# Altium schematic sheet ESP32S3_V2.1.SchDoc
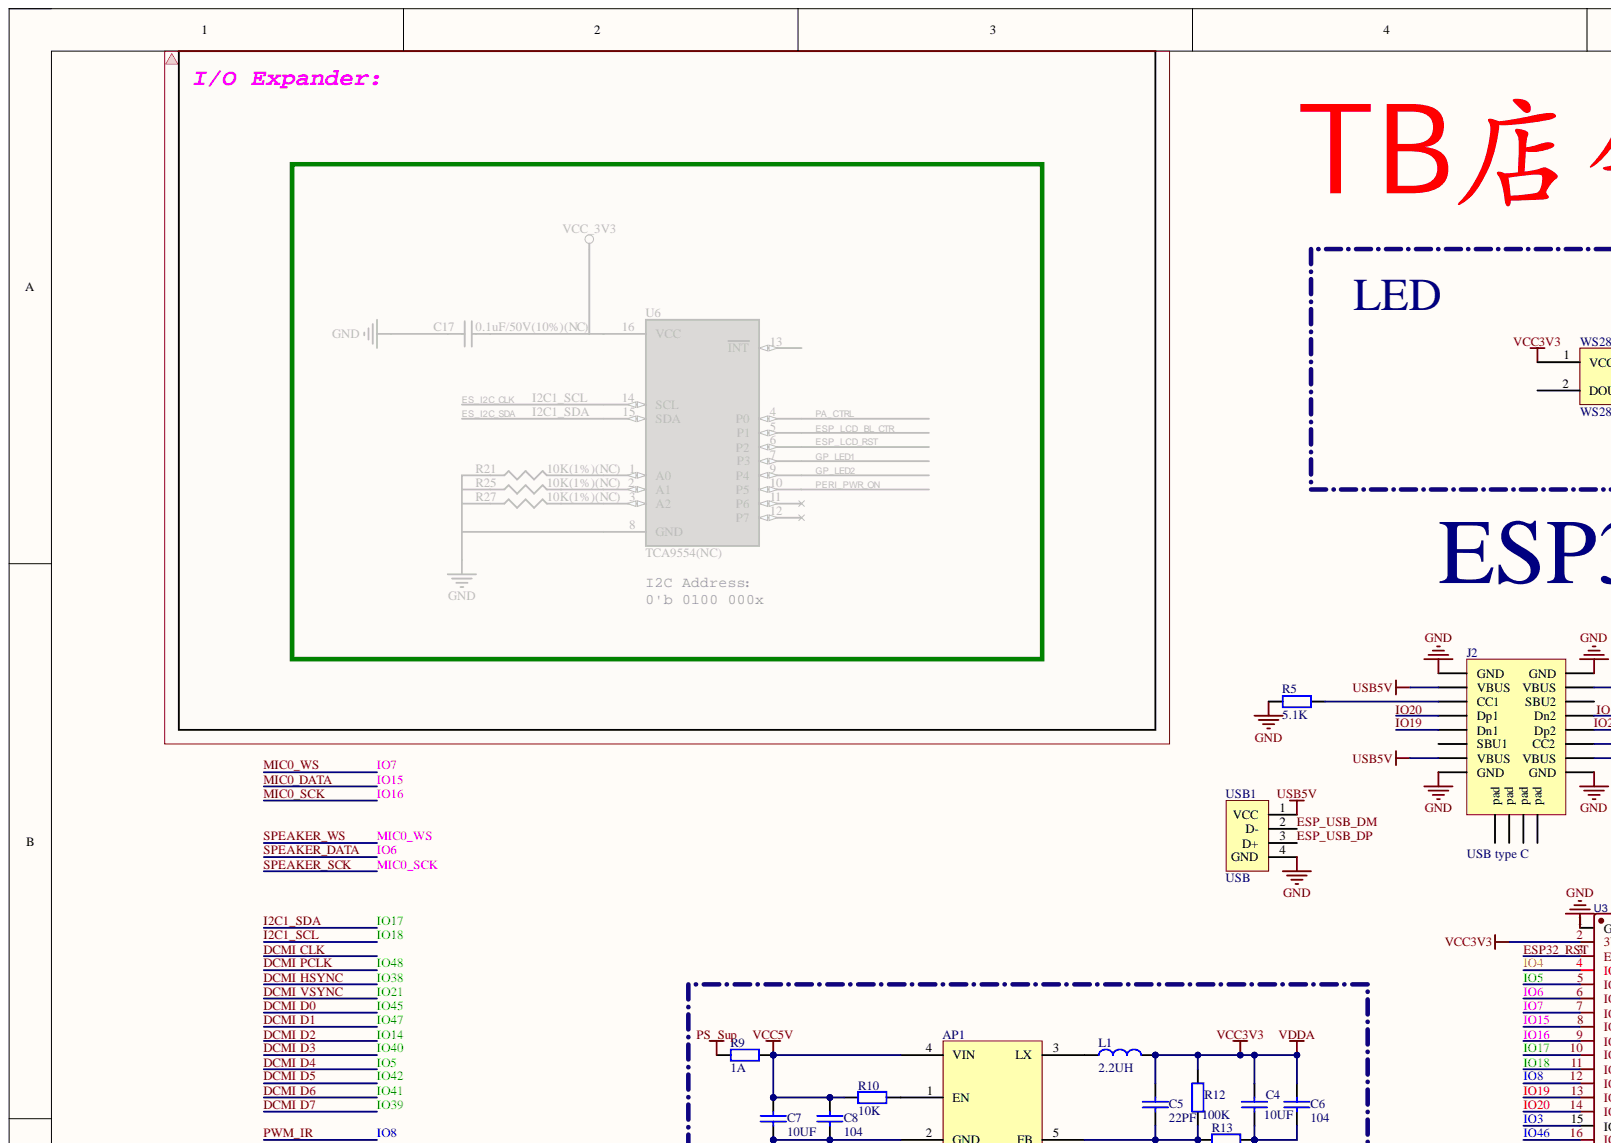
[diagram p0: ESP32S3_V2.1.SchDoc, top left]
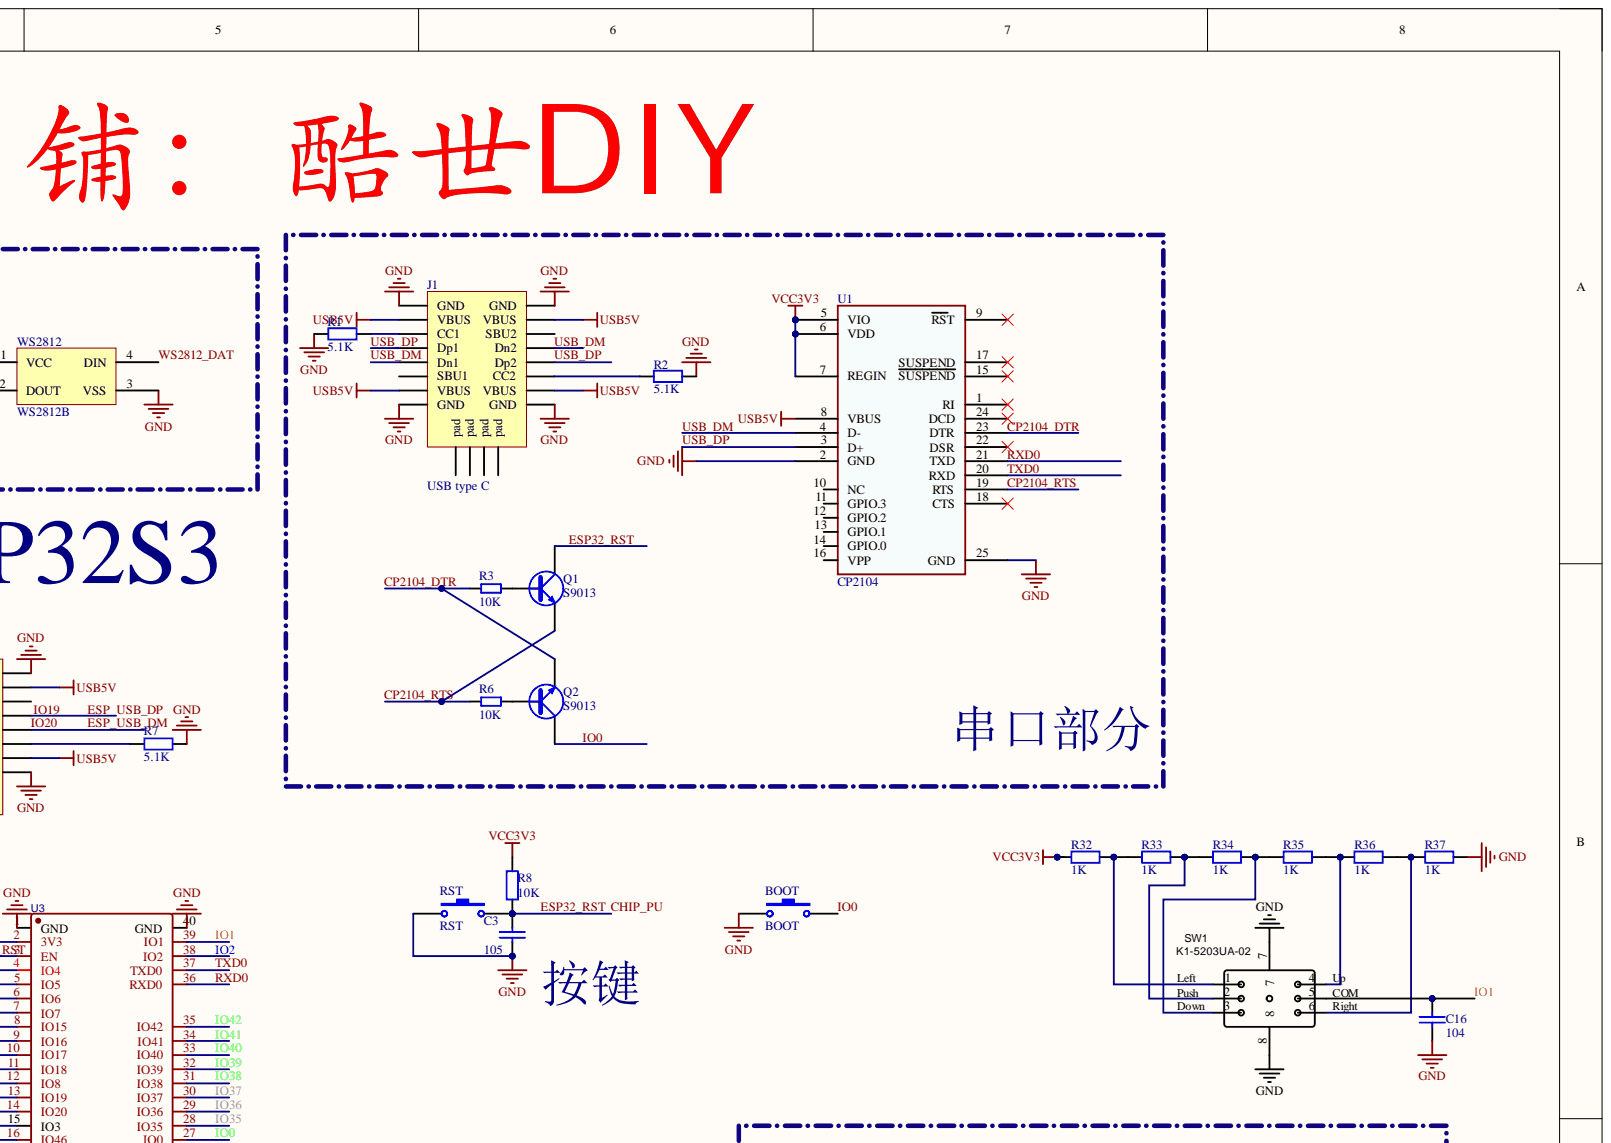
[diagram p1: ESP32S3_V2.1.SchDoc, top right]
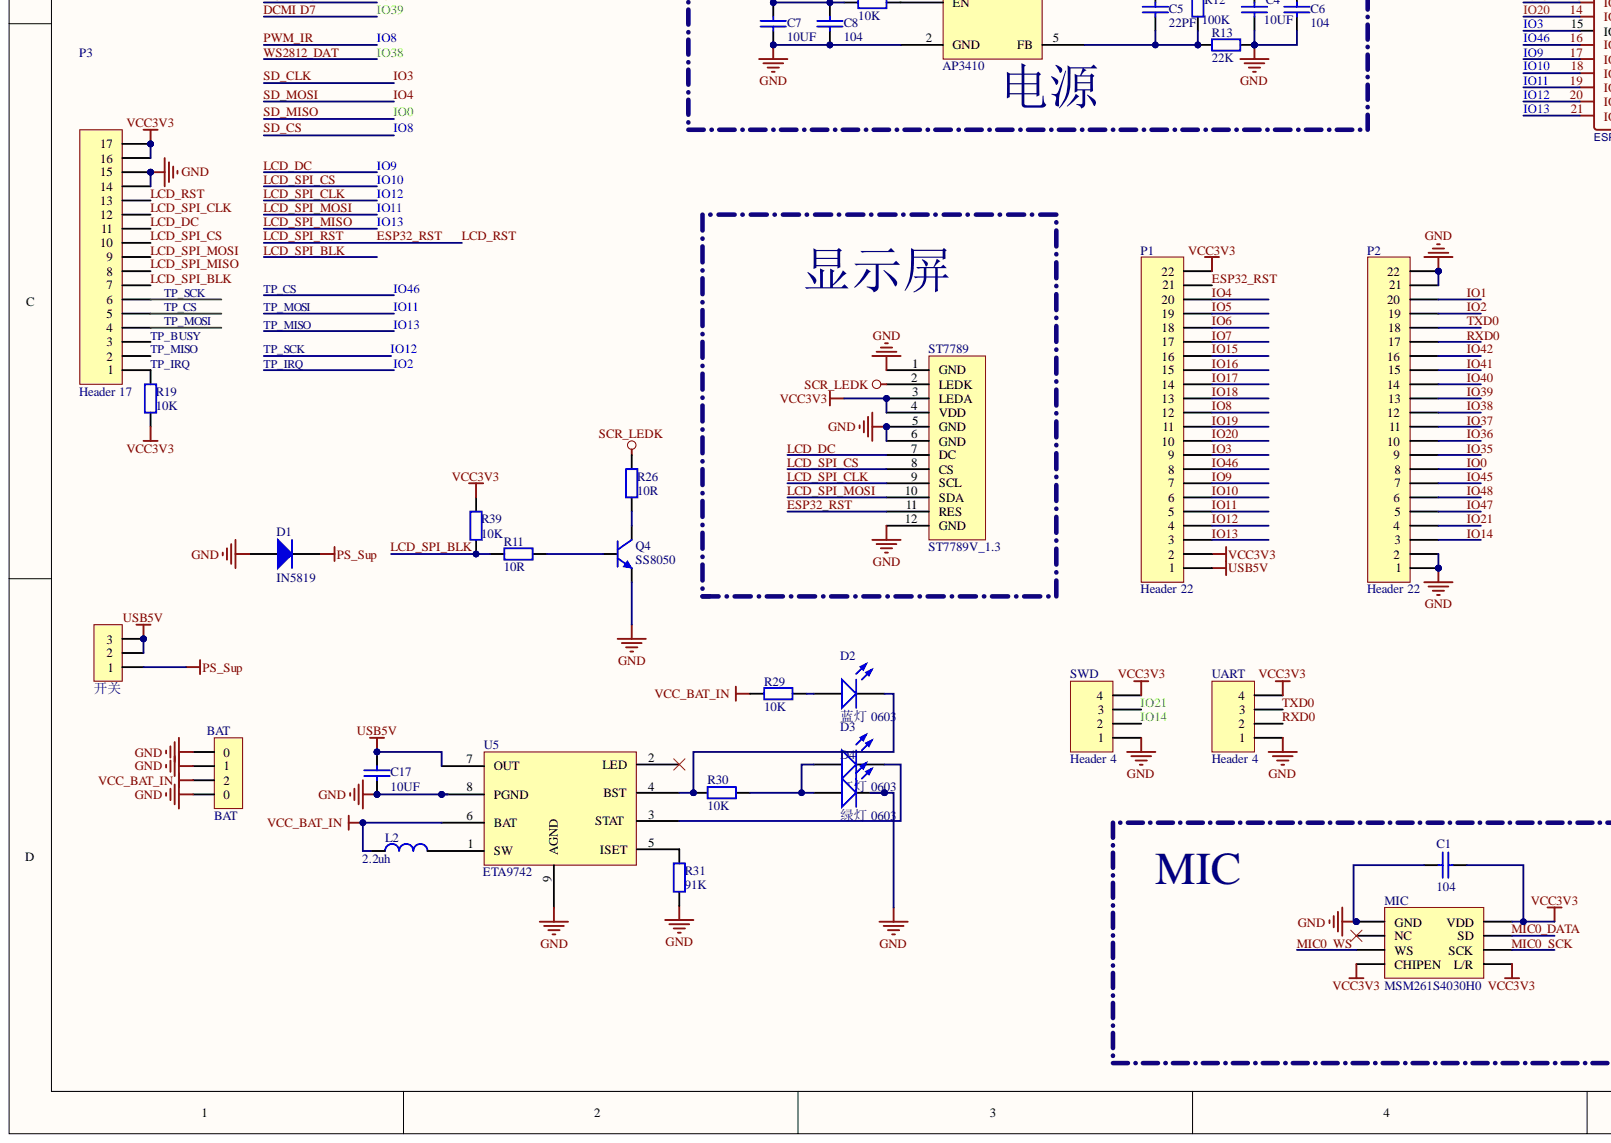
[diagram p2: ESP32S3_V2.1.SchDoc, bottom left]
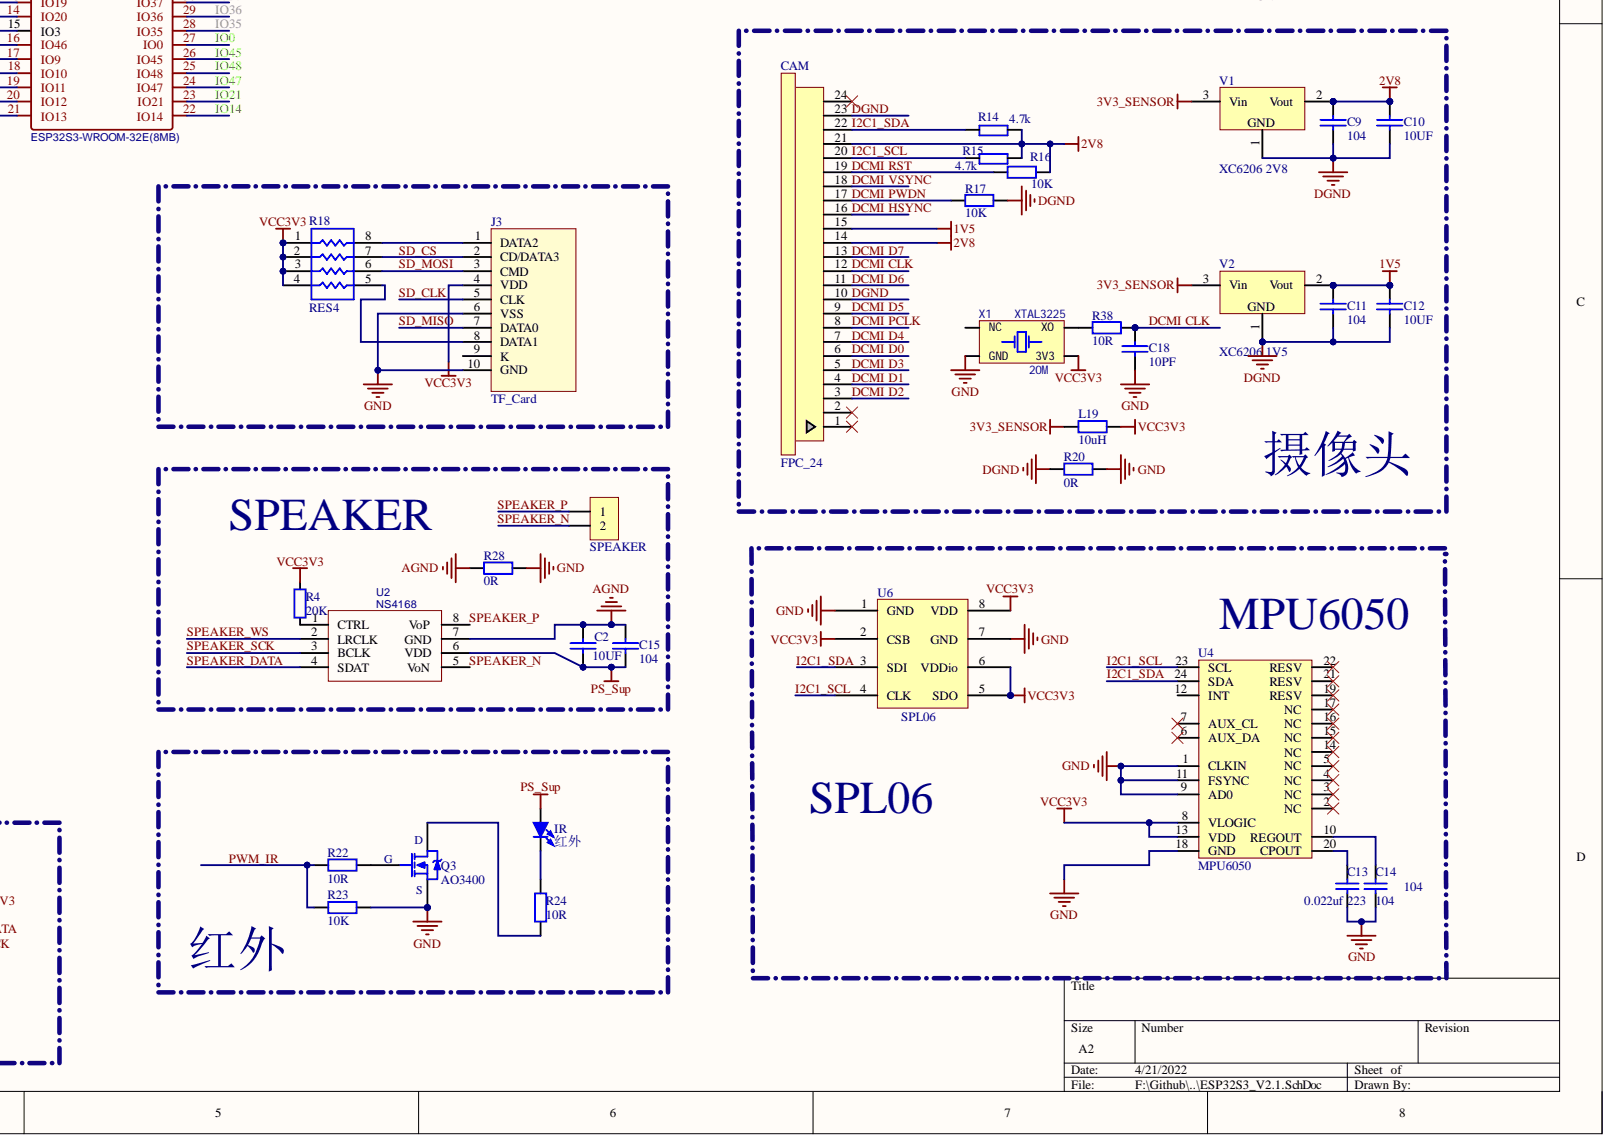
[diagram p3: ESP32S3_V2.1.SchDoc, bottom right]

## Parts
AP1  AP3410  [SOT23-5N]
BAT  BAT  [MOLEX 53398-0271]
BOOT  BOOT  [KEY_1]
C1  104  [LC-0603_C]
C2  10UF  [LC-0603_C]
C3  105  [LC-0603_C]
C4  10UF  [LC-0603_C]
C5  22PF  [LC-0603_C]
C6  104  [LC-0603_C]
C7  10UF  [LC-0603_C]
C8  104  [LC-0603_C]
C9  104  [LC-0603_C]
C10  10UF  [LC-0603_C]
C11  104  [LC-0603_C]
C12  10UF  [LC-0603_C]
C13  0.022uf  value 223  [LC-0603_C]
C14  104  [LC-0603_C]
C15  104  [LC-0603_C]
C16  104  [LC-0603_C]
C17  10UF  [LC-0603_C]
C18  10PF  [LC-0603_C]
CAM  FPC_24  [FPC_24]
D1  IN5819  [SOD-123]
D2  蓝灯 0603  Package: 0603  [0603_LED_S1]
D3  红灯 0603  Package: 0603  [0603_LED_S1]
D4  绿灯 0603  Package: 0603  [0603_LED_S1]
IR  红外  [LED_3528_RED]
J1  USB type C  [USB Type-C-16Pin_A]
J2  USB type C  [USB Type-C-16Pin_A]
J3  TF_Card  [TF卡座]
L1  2.2UH  [L-SMD-2.5*2.0*1.2MM]
L2  2.2uh  [CD32]
L19  10uH  [LC-0603_L]
MIC  MSM261S4030H0  [MSM261S4030H0]
P1  Header 22  [HDR1X22]
P2  Header 22  [HDR1X22]
P3  Header 17  [TFT 2.8 PIN]
Q1  S9013  [SOT23-3N]
Q2  S9013  [SOT23-3N]
Q3  AO3400  [SOT-23(SOT-23-3)]
Q4  SS8050  [SOT23-3N]
R1  5.1K  [LC-0603_R]
R2  5.1K  [LC-0603_R]
R3  10K  [LC-0603_R]
R4  20K  [LC-0603_R]
R5  5.1K  [LC-0603_R]
R6  10K  [LC-0603_R]
R7  5.1K  [LC-0603_R]
R8  10K  [LC-0603_R]
R9  1A  [0805_R]
R10  10K  [LC-0603_R]
R11  10R  [LC-0603_R]
R12  100K  [LC-0603_R]
R13  22K  [LC-0603_R]
R14  4.7k  [LC-0603_R]
R15  4.7k  [LC-0603_R]
R16  10K  [LC-0603_R]
R17  10K  [LC-0603_R]
R18  RES4  [RCA-8P4R-0603]
R19  10K  [LC-0603_R]
R20  0R  [LC-0603_R]
R22  10R  [LC-0603_R]
R23  10K  [LC-0603_R]
R24  10R  [LC-0603_R]
R26  10R  [LC-0603_R]
R28  0R  [LC-0603_R]
R29  10K  [LC-0603_R]
R30  10K  [LC-0603_R]
R31  91K  [LC-0603_R]
R32  1K  [LC-0603_R]
R33  1K  [LC-0603_R]
R34  1K  [LC-0603_R]
R35  1K  [LC-0603_R]
R36  1K  [LC-0603_R]
R37  1K  [LC-0603_R]
R38  10R  [LC-0603_R]
R39  10K  [LC-0603_R]
RST  RST  [KEY_1]
SPEAKER  HDR-1X2  [PH2.0-LI-2P]  (value not drawn)
ST7789  ST7789V_1.3  [ST7789V_12Pin]
SW1  K1-5203UA-02  [K1-5]
SWD  Header 4  [HDR2.54-LI-4P]
U1  CP2104  [QFN24 4x4_N]
U2  NS4168  [SOIC-8(add 9pin)]
U3  ESP32S3-WROOM-32E(8MB)  [ESP32S3-WROOM-1]
U4  MPU6050  [QFN24 4x4_N]
U5  ETA9742  [SOIC-8(add 9pin)]
U6  SPL06  [BMP280]
UART  Header 4  [HDR1.27-LI-4P]
USB1  USB  [6.48贴片USB短体母座]
V1  XC6206 2V8  [SOT23-3N]
V2  XC6206 1V5  [SOT23-3N]
WS2812  WS2812B  [LED_3528_RGB]
X1  20M  Type: XTAL3225  [XT_3225_4]
开关  HDR-1X3  [switch-mini]  (value not drawn)

## Nets
1V5: C11.1 C12.1 CAM.15 V2.2
2V8: C9.1 C10.1 CAM.14 CAM.21 R14.2 R15.2 R16.2 V1.2
3V3_SENSOR: L19.2 V1.3 V2.3
AGND: C2.2 C15.2 R28.2 U2.7(GND)
GND: AP1.2(GND) BAT.0 BAT.1 BOOT.1 C1.1 C3.1 C4.1 C6.1 C7.1 C8.1 C13.1 C14.1 C16.2 C17.2 C18.1 D1.1 D4.K J1.A1(GND) J1.A12(GND) J1.B1(GND) J1.B12(GND) J2.A1(GND) J2.A12(GND) J2.B1(GND) J2.B12(GND) J3.6(VSS) J3.10(GND) MIC.1(GND) P2.1 P2.2 P2.21 P2.22 P3.14 P3.15 Q3.2 Q4.2 R1.1 R2.1 R5.1 R7.2 R13.1 R20.1 R23.1 R28.1 R31.2 R37.2 RST.1 ST7789.1(GND) ST7789.5(GND) ST7789.6(GND) ST7789.12(GND) SW1.7 SW1.8 SWD.1 U1.2(GND) U1.25(GND) U3.1(GND) U3.40(GND) U4.1(CLKIN) U4.9(AD0) U4.11(FSYNC) U4.18(GND) U5.8(PGND) U5.9(AGND) U6.1(GND) U6.7(GND) UART.1 USB1.4(GND) WS2812.3(VSS) X1.2(GND)
PS_Sup: C2.1 C15.1 D1.2 IR.1 R9.2 U2.6(VDD) 开关.1
SCR_LEDK: R26.1 ST7789.2(LEDK)
USB5V: C17.1 J1.A4(VBUS) J1.A9(VBUS) J1.B4(VBUS) J1.B9(VBUS) J2.A4(VBUS) J2.A9(VBUS) J2.B4(VBUS) J2.B9(VBUS) P1.1 U1.8(VBUS) U5.7(OUT) USB1.1(VCC) 开关.2 开关.3
VCC3V3: C1.2 C4.2 C5.2 C6.2 J3.4(VDD) L1.1 L19.1 MIC.4(CHIPEN) MIC.5(L/R) MIC.8(VDD) P1.2 P1.22 P3.16 P3.17 R4.2 R8.1 R12.1 R18.1 R18.2 R18.3 R18.4 R19.1 R32.1 R39.1 ST7789.3(LEDA) ST7789.4(VDD) SWD.4 U1.5(VIO) U1.6(VDD) U1.7(REGIN) U3.2(3V3) U4.8(VLOGIC) U4.13(VDD) U6.2(CSB) U6.5(SDO) U6.6(VDDio) U6.8(VDD) UART.4 WS2812.1(VCC) X1.4(3V3)
VCC5V: AP1.4(VIN) C7.2 C8.2 R9.1 R10.2
VCC_BAT_IN: BAT.2 L2.1 R29.1 U5.6(BAT)
CHIP_PU: C3.2 P1.21 P3.13 Q1.3 R8.2 RST.2 ST7789.11(RES) U3.3(EN)
COM: C16.1 P2.20 SW1.5 U3.39(IO1)
CP2104_DTR: Q2.2 R3.1 U1.23(DTR)
CP2104_RTS: Q1.2 R6.1 U1.19(RTS)
DCMI CLK: C18.2 CAM.12 R38.1
DCMI D0: CAM.6 P2.7 U3.26(IO45)
DCMI D1: CAM.4 P2.5 U3.24(IO47)
DCMI D2: CAM.3 P2.3 SWD.2 U3.22(IO14)
DCMI D3: CAM.5 P2.14 U3.33(IO40)
DCMI D4: CAM.7 P1.19 U3.5(IO5)
DCMI D5: CAM.9 P2.16 U3.35(IO42)
DCMI D6: CAM.11 P2.15 U3.34(IO41)
DCMI D7: CAM.13 P2.13 U3.32(IO39)
DCMI HSYNC: CAM.16 P2.12 U3.31(IO38) WS2812.4(DIN)
DCMI PCLK: CAM.8 P2.6 U3.25(IO48)
DCMI PWDN: CAM.17 R17.1
DCMI RST: CAM.19 R16.1
DCMI VSYNC: CAM.18 P2.4 SWD.3 U3.23(IO21)
DGND: C9.2 C10.2 C11.2 C12.2 CAM.10 CAM.23 R17.2 R20.2 V1.1 V2.1
Down: R34.2 R35.1 SW1.3
ESP_USB_DM: J2.A6(Dp1) J2.B6(Dp2) P1.10 U3.14(IO20) USB1.2(D-)
ESP_USB_DP: J2.A7(Dn1) J2.B7(Dn2) P1.11 U3.13(IO19) USB1.3(D+)
I2C1_SCL: CAM.20 P1.13 R15.1 U3.11(IO18) U4.23(SCL) U6.4(CLK)
I2C1_SDA: CAM.22 P1.14 R14.1 U3.10(IO17) U4.24(SDA) U6.3(SDI)
IO0: BOOT.2 J3.7(DATA0) P2.8 Q2.3 U3.27(IO0)
IO2: P2.19 P3.1 R19.2 U3.38(IO2)
IO3: J3.5(CLK) P1.9 U3.15(IO3)
IO4: J3.3(CMD) P1.20 R18.6 U3.4(IO4)
IO6: P1.18 U2.4(SDAT) U3.6(IO6)
IO7: MIC.3(WS) P1.17 U2.2(LRCLK) U3.7(IO7)
IO8: J3.2(CD/DATA3) P1.12 R18.7 R22.2 R23.2 U3.12(IO8)
IO9: P1.7 P3.11 ST7789.7(DC) U3.17(IO9)
IO10: P1.6 P3.10 ST7789.8(CS) U3.18(IO10)
IO11: P1.5 P3.4 P3.9 ST7789.10(SDA) U3.19(IO11)
IO12: P1.4 P3.6 P3.12 ST7789.9(SCL) U3.20(IO12)
IO13: P1.3 P3.2 P3.8 U3.21(IO13)
IO15: MIC.7(SD) P1.16 U3.8(IO15)
IO16: MIC.6(SCK) P1.15 U2.3(BCLK) U3.9(IO16)
IO35: P2.9 U3.28(IO35)
IO36: P2.10 U3.29(IO36)
IO37: P2.11 U3.30(IO37)
IO46: P1.8 P3.5 U3.16(IO46)
LCD_SPI_BLK: P3.7 R11.1 R39.2
Left: R32.2 R33.1 SW1.1
Push: R33.2 R34.1 SW1.2
Right: R36.2 R37.1 SW1.6
RXD0: P2.17 U1.21(TXD) U3.36(RXD0) UART.2
SPEAKER_N: SPEAKER.2 U2.5(VoN)
SPEAKER_P: SPEAKER.1 U2.8(VoP)
TP_BUSY: P3.3
TXD0: P2.18 U1.20(RXD) U3.37(TXD0) UART.3
Up: R35.2 R36.1 SW1.4
USB_DM: J1.A7(Dn1) J1.B7(Dn2) U1.4(D-)
USB_DP: J1.A6(Dp1) J1.B6(Dp2) U1.3(D+)
NetAP1_1: AP1.1(EN) R10.1
NetAP1_3: AP1.3(LX) L1.2
NetAP1_5: AP1.5(FB) C5.1 R12.2 R13.2
NetC13_2: C13.2 U4.20(CPOUT)
NetC14_2: C14.2 U4.10(REGOUT)
NetD2_A: D2.A R29.2
NetD2_K: D2.K R30.1 U5.4(BST)
NetD3_A: D3.A D4.A R30.2
NetD3_K: D3.K U5.3(STAT)
NetIR_2: IR.2 R24.1
NetJ1_A5: J1.A5(CC1) R1.2
NetJ1_B5: J1.B5(CC2) R2.2
NetJ2_A5: J2.A5(CC1) R5.2
NetJ2_B5: J2.B5(CC2) R7.1
NetJ3_1: J3.1(DATA2) R18.8
NetJ3_8: J3.8(DATA1) R18.5
NetL2_2: L2.2 U5.1(SW)
NetQ1_1: Q1.1 R3.2
NetQ2_1: Q2.1 R6.2
NetQ3_1: Q3.1 R22.1
NetQ3_3: Q3.3 R24.2
NetQ4_1: Q4.1 R11.2
NetQ4_3: Q4.3 R26.2
NetR4_1: R4.1 U2.1(CTRL)
NetR31_1: R31.1 U5.5(ISET)
NetR38_2: R38.2 X1.3(XO)

Not connected: CAM 1 2 24; J1 0(pad) A8(SBU1) B8(SBU2); J2 0(pad) A8(SBU1) B8(SBU2); J3 9(K); MIC 2(NC); U1 1(RI) 9(RST) 10(NC) 11(GPIO.3) 12(GPIO.2) 13(GPIO.1) 14(GPIO.0) 15(SUSPEND) 16(VPP) 17(SUSPEND) 18(CTS) 22(DSR) 24(DCD); U4 2(NC) 3(NC) 4(NC) 5(NC) 6(AUX_DA) 7(AUX_CL) 12(INT) 14(NC) 15(NC) 16(NC) 17(NC) 19(RESV) 21(RESV) 22(RESV); U5 2(LED); WS2812 2(DOUT); X1 1(NC)

## Library data of the parts
BAT = Header 4: molex 53261-0271 2P; BOM名称: 4P接插件; 版权归属: 源创客; Component Kind: Standard; Library Name: 3D Mode.IntLib; 学习资源: www.ooocke.com
BOOT RST = SW-PB: Switch; Component Kind: Standard; LatestRevisionDate: 17-Jul-2002; LatestRevisionNote: Re-released for DXP Platform.; PackageDescription: Switch; 2 Leads; PackageReference: SPST-2; Published: 24-Mar-1999; Library Name: 自创 (1).SchLib; Publisher: Altium Limited
C1 C9-C12 C15-C17 = CAP: Component Kind: Standard; Library Name: XKZ.SchLib
C2 C4-C8 C18 = cap: Component Kind: Standard; Library Name: 自创.SchLib
C3 = C: Capacitor; Component Kind: Standard; Library Name: Tribrer_GENERAL.SchLib
C13 C14 = Cap: Capacitor; Component Kind: Standard; LatestRevisionDate: 17-Jul-2002; LatestRevisionNote: Re-released for DXP Platform.; Library Name: Miscellaneous Devices.IntLib; PackageDescription: Radial Cap, Thru-Hole; 2 Leads; 0.3 in Pin Spacing; PackageReference: RAD-0.3; Published: 8-Jun-2000; Signal Integrity: Cap; Simulation: CAP; Publisher: Altium Limited
  C14: Value: 104
D1 = SMA(DO-214AC) SS54: 贴片二极管 SOD-123; Component Kind: Standard; ComponentLink1Description: 供应商链接; ComponentLink1URL: http://www.szlcsc.com/product/details_23178.html; Library Name: Miscellaneous Devices LC.IntLib; Package: SMA(DO-214AC); Supplier: LC; SuppliersPartNumber: C22452
D2-D4 = 0603 白灯: 发光二极管; Component Kind: Standard; ComponentLink1Description: 供应商链接; ComponentLink1URL: http://www.szlcsc.com/product/details_2663.html; Library Name: Miscellaneous Devices LC.IntLib; Supplier: LC; SuppliersPartNumber: C2290
IR = LED-3MM: 插件红外发射管3mm; BOM名称: 插件LED; 版权归属: 源创客; Component Kind: Standard; Library Name: 3D库.IntLib; 学习资源: www.ooocke.com
J1 J2 = USB_C: Component Kind: Standard; Library Name: XKZ.SchLib
J3 = TF_Card: TF卡座; 版权归属: 源创客; BOM名称: TF卡座; Component Kind: Standard; Library Name: Source.IntLib; 学习资源: www.ooocke.com
L1 = L1: 电感; Component Kind: Standard; Library Name: XKZ.SchLib
L2 = L: 贴片功率电感 CD32; Component Kind: Standard; Library Name: QDC.SchLib
L19 R1 R2 R5 R7-R13 R16 R17 R19 R20 R22-R24 R26 R28-R39 = RES: 普通电阻; Component Kind: Standard; Library Name: LIB_MANGO.IntLib
P1 P2 = Header 22: Header, 22-Pin; Component Kind: Standard; LatestRevisionDate: 17-Jul-2002; LatestRevisionNote: Re-released for DXP Platform.; Library Name: Miscellaneous Connectors.IntLib; Signal Integrity: Connector; Publisher: Altium Limited
P3 = Header 17: Header, 17-Pin; Component Kind: Standard; LatestRevisionDate: 17-Jul-2002; LatestRevisionNote: Re-released for DXP Platform.; Library Name: Miscellaneous Connectors.IntLib; Signal Integrity: Connector; Publisher: Altium Limited
Q1 Q2 = Q S9013: Component Kind: Standard; Library Name: GENERAL.SchLib
Q3 = NPN DIAC: 贴片MOS管; Component Kind: Standard
Q4 = 8050-SMD: 高频放大-NPN型; 属性: 40V 1.5A 1W 100MHZ; 版权归属: 源创客; BOM名称: 高频放大-NPN型; Component Kind: Standard; Library Name: 3D库.IntLib; 学习资源: www.ooocke.com
R3 R6 = R_0Ω_0603: Component Kind: Standard; Library Name: Rtnzero_HW_Lib.IntLib
R4 = 电阻-0402: Resistor; Value: 22R; Component Kind: Standard; Library Name: MyPcbLib.IntLib
R14 R15 = R_1: Component Kind: Standard; Library Name: Explorer STM32F407封装库.IntLib; value: 4.7K
SPEAKER = Header 2: 2P接插件; 版权归属: 源创客; BOM名称: 2P接插件; Component Kind: Standard; Library Name: 4.2   - 接插件-脚距1.25.SchLib; 学习资源: www.ooocke.com
SW1 = K1-5203UA-02: BOM_Manufacturer: HRO; Contributor: LCSC; BOM_Manufacturer Part: K1-5203UA-02; BOM_Supplier: LCSC; BOM_Supplier Part: C145898; Component Kind: Standard; spicePre: S; spiceSymbolName: K1-5203UA-02
SWD UART = Header 4: Header, 4-Pin; Component Kind: Standard; LatestRevisionDate: 17-Jul-2002; LatestRevisionNote: Re-released for DXP Platform.; Library Name: Miscellaneous Connectors.IntLib; Signal Integrity: Connector; Publisher: Altium Limited
U2 = NS4168: Contributor: wjlgan; Component Kind: Standard; spicePre: U; spiceSymbolName: NS4168
U3 = ESP32S3-WROOM-1: BOM_Manufacturer: Espressif Systems; BOM_Manufacturer Part: ESP32-WROOM-32E(8MB); Contributor: LCSC; BOM_JLCPCB Part Class: Extended Part; BOM_Supplier: LCSC; BOM_Supplier Part: C701342; Component Kind: Standard; spicePre: U; spiceSymbolName: ESP32-WROOM-32E(8MB); Library Name: XKZ.SchLib
U4 = MPU6050: 9轴运动处理传感器; Component Kind: Standard; Library Name: Explorer STM32F407封装库.IntLib
U6 = BMP280: Component Kind: Standard; Library Name: NT-SchLibrary.SchLib
USB1 = USB-A/S: USB-A型母接口; 版权归属: 源创客; BOM名称: USB-A型母接口; Component Kind: Standard; Library Name: 4.1   - 接口类.SchLib; 学习资源: www.ooocke.com
V1 V2 = XC6206P332MR: 低压差线性稳压芯片; 版权归属: 源创客; BOM名称: 低压差线性稳压芯片; Component Kind: Standard; 学习资源: www.ooocke.com; Library Name: XKZ.SchLib
X1 = XTAL_2520_4P: Crystal Oscillator; Component Kind: Standard; Library Name: SCH_Oscillator.SchLib; Value: 20M
开关 = Header 3: 拨动开关 插件卧式 1P2T SK12D07VG3 3MM柄高 小型拨动开关 三脚/两档; BOM名称: 3P接插件; 版权归属: 源创客; Component Kind: Standard; Library Name: 3D库.IntLib; 学习资源: www.ooocke.com
AP1 = AP3410: Component Kind: Standard; Library Name: XKZ.SchLib
CAM = FPC_24: Component Kind: Standard; Library Name: XKZ.SchLib
MIC = MSM261S4030H0: Component Kind: Standard; Library Name: XKZ.SchLib
R18 = RES4: Component Kind: Standard; Library Name: LONG_lclr.SchLib
ST7789 = ST7789V_1.3: Component Kind: Standard; Library Name: XKZ.SchLib
U1 = CP2104: Component Kind: Standard; Library Name: LIB_MANGO.IntLib
U5 = ETA9742: Component Kind: Standard; Library Name: LONG_lclr.SchLib
WS2812 = WS2812B: Component Kind: Standard; Library Name: XKZ.SchLib
